# Altium schematic sheet Control Loops.SchDoc
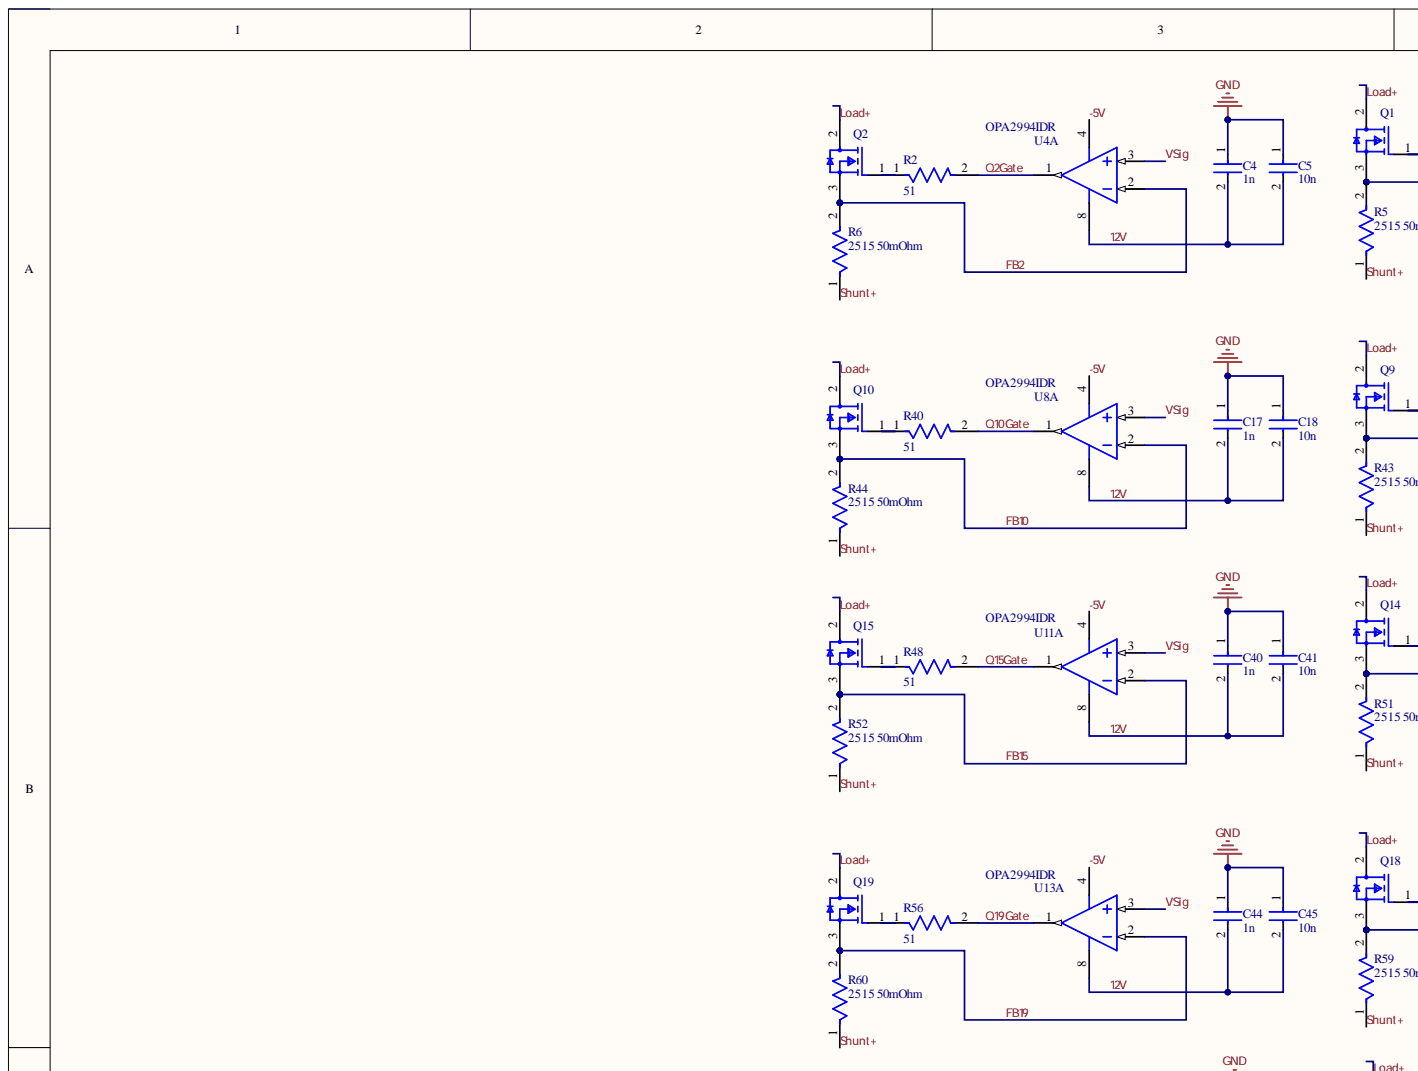
[diagram p0: Control Loops.SchDoc, top left]
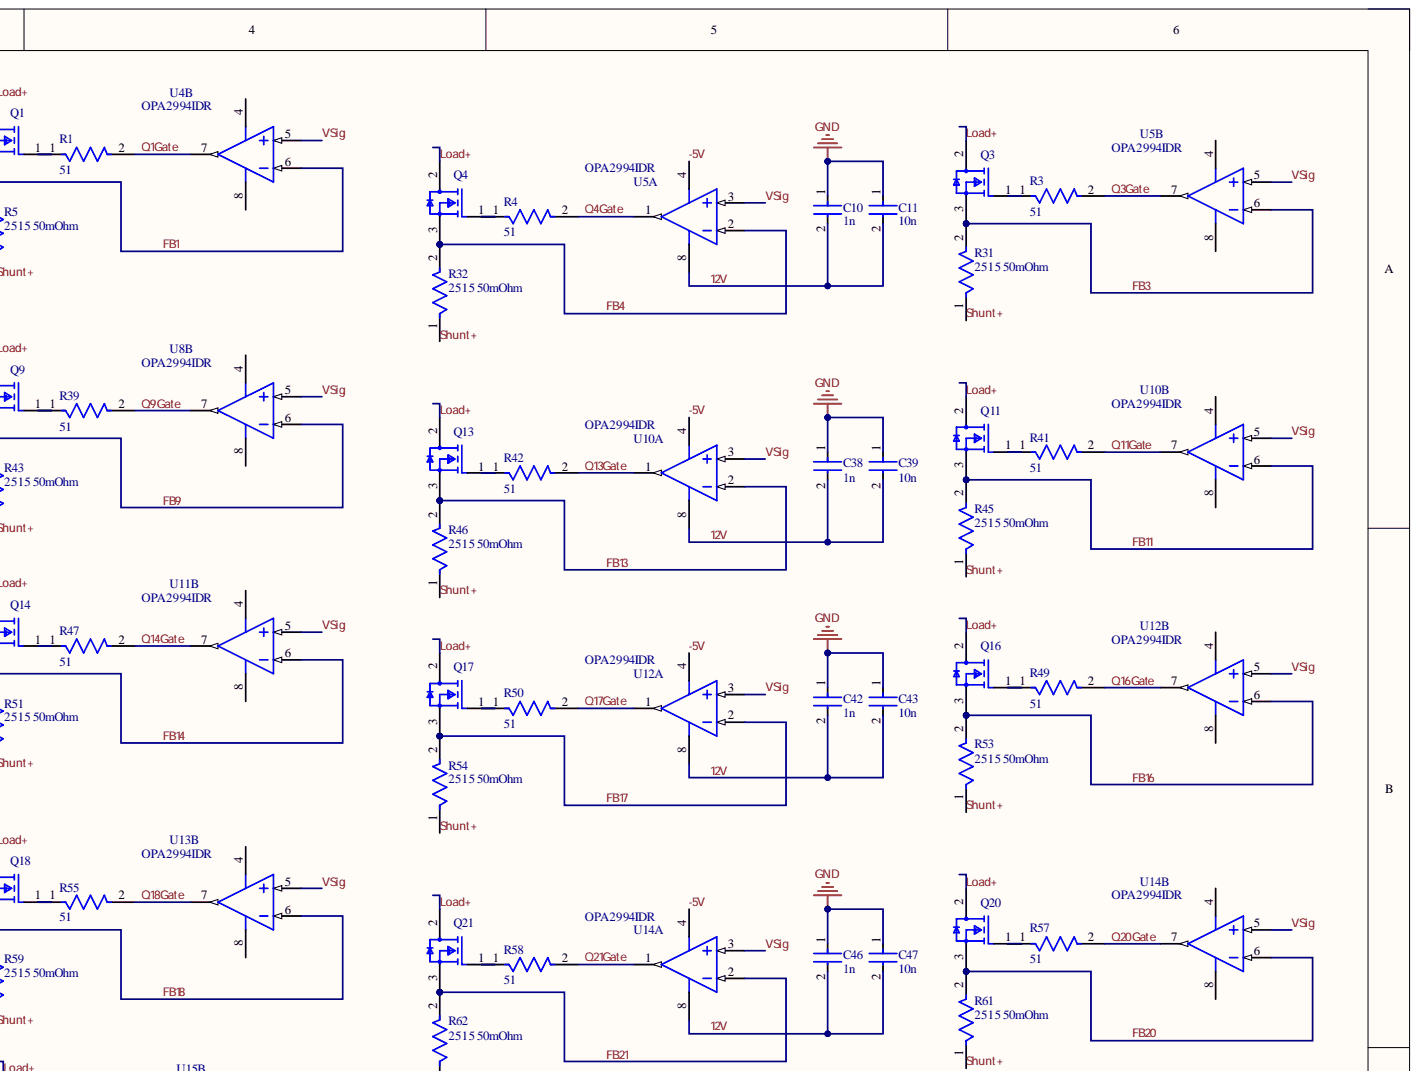
[diagram p1: Control Loops.SchDoc, top right]
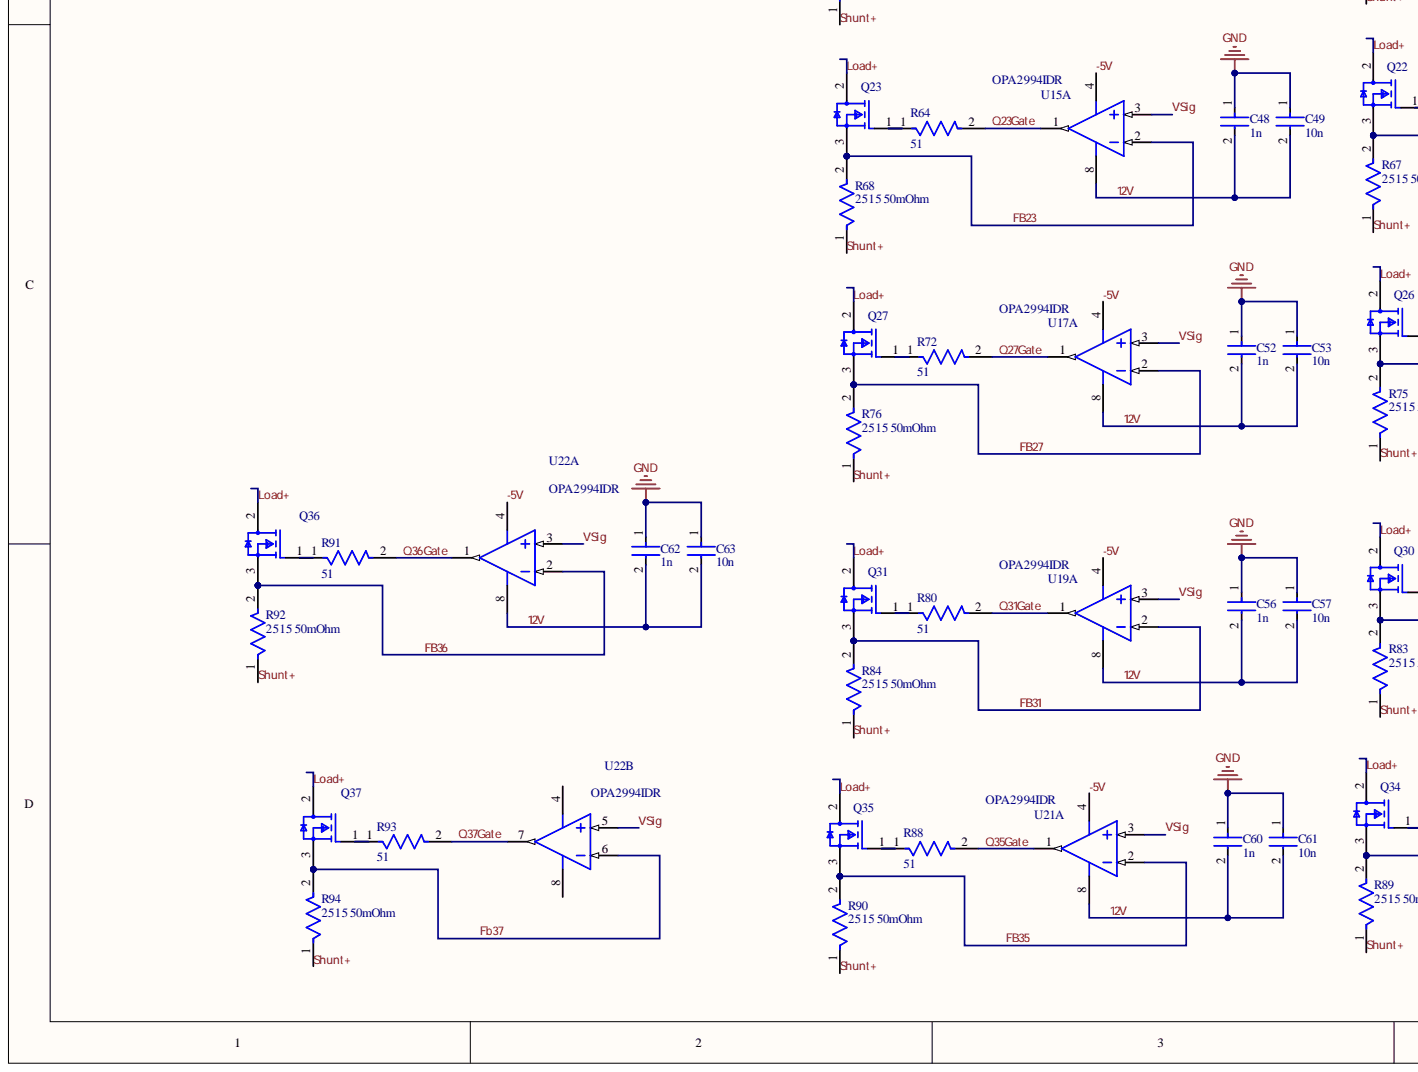
[diagram p2: Control Loops.SchDoc, bottom left]
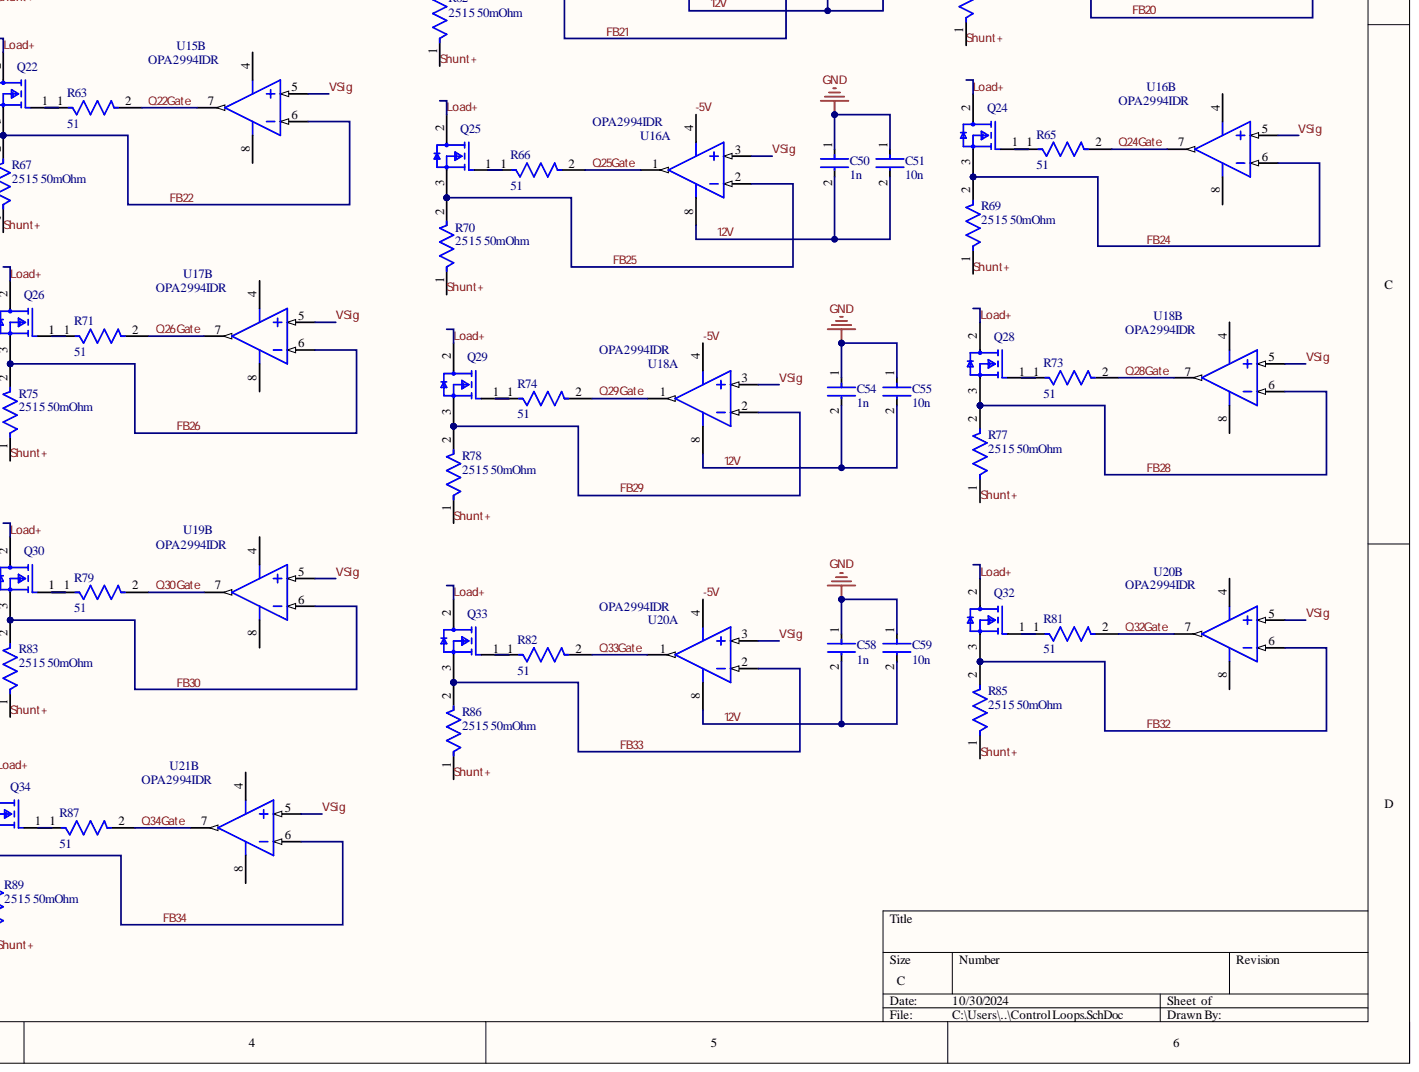
[diagram p3: Control Loops.SchDoc, bottom right]

## Parts
C4  1n  [FP-C1206C-EB-MFG]
C5  10n  [FP-C1206C-EB-MFG]
C10  1n  [FP-C1206C-EB-MFG]
C11  10n  [FP-C1206C-EB-MFG]
C17  1n  [FP-C1206C-EB-MFG]
C18  10n  [FP-C1206C-EB-MFG]
C38  1n  [FP-C1206C-EB-MFG]
C39  10n  [FP-C1206C-EB-MFG]
C40  1n  [FP-C1206C-EB-MFG]
C41  10n  [FP-C1206C-EB-MFG]
C42  1n  [FP-C1206C-EB-MFG]
C43  10n  [FP-C1206C-EB-MFG]
C44  1n  [FP-C1206C-EB-MFG]
C45  10n  [FP-C1206C-EB-MFG]
C46  1n  [FP-C1206C-EB-MFG]
C47  10n  [FP-C1206C-EB-MFG]
C48  1n  [FP-C1206C-EB-MFG]
C49  10n  [FP-C1206C-EB-MFG]
C50  1n  [FP-C1206C-EB-MFG]
C51  10n  [FP-C1206C-EB-MFG]
C52  1n  [FP-C1206C-EB-MFG]
C53  10n  [FP-C1206C-EB-MFG]
C54  1n  [FP-C1206C-EB-MFG]
C55  10n  [FP-C1206C-EB-MFG]
C56  1n  [FP-C1206C-EB-MFG]
C57  10n  [FP-C1206C-EB-MFG]
C58  1n  [FP-C1206C-EB-MFG]
C59  10n  [FP-C1206C-EB-MFG]
C60  1n  [FP-C1206C-EB-MFG]
C61  10n  [FP-C1206C-EB-MFG]
C62  1n  [FP-C1206C-EB-MFG]
C63  10n  [FP-C1206C-EB-MFG]
Q1  NVHL027N65S3F  [FP-340CX-MFG]  (value not drawn)
Q2  NVHL027N65S3F  [FP-340CX-MFG]  (value not drawn)
Q3  NVHL027N65S3F  [FP-340CX-MFG]  (value not drawn)
Q4  NVHL027N65S3F  [FP-340CX-MFG]  (value not drawn)
Q9  NVHL027N65S3F  [FP-340CX-MFG]  (value not drawn)
Q10  NVHL027N65S3F  [FP-340CX-MFG]  (value not drawn)
Q11  NVHL027N65S3F  [FP-340CX-MFG]  (value not drawn)
Q13  NVHL027N65S3F  [FP-340CX-MFG]  (value not drawn)
Q14  NVHL027N65S3F  [FP-340CX-MFG]  (value not drawn)
Q15  NVHL027N65S3F  [FP-340CX-MFG]  (value not drawn)
Q16  NVHL027N65S3F  [FP-340CX-MFG]  (value not drawn)
Q17  NVHL027N65S3F  [FP-340CX-MFG]  (value not drawn)
Q18  NVHL027N65S3F  [FP-340CX-MFG]  (value not drawn)
Q19  NVHL027N65S3F  [FP-340CX-MFG]  (value not drawn)
Q20  NVHL027N65S3F  [FP-340CX-MFG]  (value not drawn)
Q21  NVHL027N65S3F  [FP-340CX-MFG]  (value not drawn)
Q22  NVHL027N65S3F  [FP-340CX-MFG]  (value not drawn)
Q23  NVHL027N65S3F  [FP-340CX-MFG]  (value not drawn)
Q24  NVHL027N65S3F  [FP-340CX-MFG]  (value not drawn)
Q25  NVHL027N65S3F  [FP-340CX-MFG]  (value not drawn)
Q26  NVHL027N65S3F  [FP-340CX-MFG]  (value not drawn)
Q27  NVHL027N65S3F  [FP-340CX-MFG]  (value not drawn)
Q28  NVHL027N65S3F  [FP-340CX-MFG]  (value not drawn)
Q29  NVHL027N65S3F  [FP-340CX-MFG]  (value not drawn)
Q30  NVHL027N65S3F  [FP-340CX-MFG]  (value not drawn)
Q31  NVHL027N65S3F  [FP-340CX-MFG]  (value not drawn)
Q32  NVHL027N65S3F  [FP-340CX-MFG]  (value not drawn)
Q33  NVHL027N65S3F  [FP-340CX-MFG]  (value not drawn)
Q34  NVHL027N65S3F  [FP-340CX-MFG]  (value not drawn)
Q35  NVHL027N65S3F  [FP-340CX-MFG]  (value not drawn)
Q36  NVHL027N65S3F  [FP-340CX-MFG]  (value not drawn)
Q37  NVHL027N65S3F  [FP-340CX-MFG]  (value not drawn)
R1  51  [FP-RC1206-MFG]
R2  51  [FP-RC1206-MFG]
R3  51  [FP-RC1206-MFG]
R4  51  [FP-RC1206-MFG]
R5  2515 50mOhm  [FP-CSRN2512-IPC_A]
R6  2515 50mOhm  [FP-CSRN2512-IPC_A]
R31  2515 50mOhm  [FP-CSRN2512-IPC_A]
R32  2515 50mOhm  [FP-CSRN2512-IPC_A]
R39  51  [FP-RC1206-MFG]
R40  51  [FP-RC1206-MFG]
R41  51  [FP-RC1206-MFG]
R42  51  [FP-RC1206-MFG]
R43  2515 50mOhm  [FP-CSRN2512-IPC_A]
R44  2515 50mOhm  [FP-CSRN2512-IPC_A]
R45  2515 50mOhm  [FP-CSRN2512-IPC_A]
R46  2515 50mOhm  [FP-CSRN2512-IPC_A]
R47  51  [FP-RC1206-MFG]
R48  51  [FP-RC1206-MFG]
R49  51  [FP-RC1206-MFG]
R50  51  [FP-RC1206-MFG]
R51  2515 50mOhm  [FP-CSRN2512-IPC_A]
R52  2515 50mOhm  [FP-CSRN2512-IPC_A]
R53  2515 50mOhm  [FP-CSRN2512-IPC_A]
R54  2515 50mOhm  [FP-CSRN2512-IPC_A]
R55  51  [FP-RC1206-MFG]
R56  51  [FP-RC1206-MFG]
R57  51  [FP-RC1206-MFG]
R58  51  [FP-RC1206-MFG]
R59  2515 50mOhm  [FP-CSRN2512-IPC_A]
R60  2515 50mOhm  [FP-CSRN2512-IPC_A]
R61  2515 50mOhm  [FP-CSRN2512-IPC_A]
R62  2515 50mOhm  [FP-CSRN2512-IPC_A]
R63  51  [FP-RC1206-MFG]
R64  51  [FP-RC1206-MFG]
R65  51  [FP-RC1206-MFG]
R66  51  [FP-RC1206-MFG]
R67  2515 50mOhm  [FP-CSRN2512-IPC_A]
R68  2515 50mOhm  [FP-CSRN2512-IPC_A]
R69  2515 50mOhm  [FP-CSRN2512-IPC_A]
R70  2515 50mOhm  [FP-CSRN2512-IPC_A]
R71  51  [FP-RC1206-MFG]
R72  51  [FP-RC1206-MFG]
R73  51  [FP-RC1206-MFG]
R74  51  [FP-RC1206-MFG]
R75  2515 50mOhm  [FP-CSRN2512-IPC_A]
R76  2515 50mOhm  [FP-CSRN2512-IPC_A]
R77  2515 50mOhm  [FP-CSRN2512-IPC_A]
R78  2515 50mOhm  [FP-CSRN2512-IPC_A]
R79  51  [FP-RC1206-MFG]
R80  51  [FP-RC1206-MFG]
R81  51  [FP-RC1206-MFG]
R82  51  [FP-RC1206-MFG]
R83  2515 50mOhm  [FP-CSRN2512-IPC_A]
R84  2515 50mOhm  [FP-CSRN2512-IPC_A]
R85  2515 50mOhm  [FP-CSRN2512-IPC_A]
R86  2515 50mOhm  [FP-CSRN2512-IPC_A]
R87  51  [FP-RC1206-MFG]
R88  51  [FP-RC1206-MFG]
R89  2515 50mOhm  [FP-CSRN2512-IPC_A]
R90  2515 50mOhm  [FP-CSRN2512-IPC_A]
R91  51  [FP-RC1206-MFG]
R92  2515 50mOhm  [FP-CSRN2512-IPC_A]
R93  51  [FP-RC1206-MFG]
R94  2515 50mOhm  [FP-CSRN2512-IPC_A]
U4  OPA2994IDR  [D0008A_N]  (parts A,B)
U5  OPA2994IDR  [D0008A_N]  (parts A,B)
U8  OPA2994IDR  [D0008A_N]  (parts A,B)
U10  OPA2994IDR  [D0008A_N]  (parts A,B)
U11  OPA2994IDR  [D0008A_N]  (parts A,B)
U12  OPA2994IDR  [D0008A_N]  (parts A,B)
U13  OPA2994IDR  [D0008A_N]  (parts A,B)
U14  OPA2994IDR  [D0008A_N]  (parts A,B)
U15  OPA2994IDR  [D0008A_N]  (parts A,B)
U16  OPA2994IDR  [D0008A_N]  (parts A,B)
U17  OPA2994IDR  [D0008A_N]  (parts A,B)
U18  OPA2994IDR  [D0008A_N]  (parts A,B)
U19  OPA2994IDR  [D0008A_N]  (parts A,B)
U20  OPA2994IDR  [D0008A_N]  (parts A,B)
U21  OPA2994IDR  [D0008A_N]  (parts A,B)
U22  OPA2994IDR  [D0008A_N]  (parts A,B)

## Nets
GND: C4.1 C5.1 C10.1 C11.1 C17.1 C18.1 C38.1 C39.1 C40.1 C41.1 C42.1 C43.1 C44.1 C45.1 C46.1 C47.1 C48.1 C49.1 C50.1 C51.1 C52.1 C53.1 C54.1 C55.1 C56.1 C57.1 C58.1 C59.1 C60.1 C61.1 C62.1 C63.1
12V: C4.2 C5.2 C10.2 C11.2 C17.2 C18.2 C38.2 C39.2 C40.2 C41.2 C42.2 C43.2 C44.2 C45.2 C46.2 C47.2 C48.2 C49.2 C50.2 C51.2 C52.2 C53.2 C54.2 C55.2 C56.2 C57.2 C58.2 C59.2 C60.2 C61.2 C62.2 C63.2 U4.8 U5.8 U8.8 U10.8 U11.8 U12.8 U13.8 U14.8 U15.8 U16.8 U17.8 U18.8 U19.8 U20.8 U21.8 U22.8
-5V: U4.4 U5.4 U8.4 U10.4 U11.4 U12.4 U13.4 U14.4 U15.4 U16.4 U17.4 U18.4 U19.4 U20.4 U21.4 U22.4
FB1: Q1.3 Q37.3 R5.2 R94.2 U4.6 U22.6  [also labelled Fb37]
FB2: Q2.3 R6.2 U4.2
FB3: Q3.3 R31.2 U5.6
FB4: Q4.3 R32.2 U5.2
FB9: Q9.3 R43.2 U8.6
FB10: Q10.3 R44.2 U8.2
FB11: Q11.3 R45.2 U10.6
FB13: Q13.3 R46.2 U10.2
FB14: Q14.3 R51.2 U11.6
FB15: Q15.3 R52.2 U11.2
FB16: Q16.3 R53.2 U12.6
FB17: Q17.3 R54.2 U12.2
FB18: Q18.3 R59.2 U13.6
FB19: Q19.3 R60.2 U13.2
FB20: Q20.3 R61.2 U14.6
FB21: Q21.3 R62.2 U14.2
FB22: Q22.3 R67.2 U15.6
FB23: Q23.3 R68.2 U15.2
FB24: Q24.3 R69.2 U16.6
FB25: Q25.3 R70.2 U16.2
FB26: Q26.3 R75.2 U17.6
FB27: Q27.3 R76.2 U17.2
FB28: Q28.3 R77.2 U18.6
FB29: Q29.3 R78.2 U18.2
FB30: Q30.3 R83.2 U19.6
FB31: Q31.3 R84.2 U19.2
FB32: Q32.3 R85.2 U20.6
FB33: Q33.3 R86.2 U20.2
FB34: Q34.3 R89.2 U21.6
FB35: Q35.3 R90.2 U21.2
FB36: Q36.3 R92.2 U22.2
Load+: Q1.2 Q2.2 Q3.2 Q4.2 Q9.2 Q10.2 Q11.2 Q13.2 Q14.2 Q15.2 Q16.2 Q17.2 Q18.2 Q19.2 Q20.2 Q21.2 Q22.2 Q23.2 Q24.2 Q25.2 Q26.2 Q27.2 Q28.2 Q29.2 Q30.2 Q31.2 Q32.2 Q33.2 Q34.2 Q35.2 Q36.2 Q37.2
Q1Gate: R1.2 U4.7
Q2Gate: R2.2 U4.1
Q3Gate: R3.2 U5.7(Q3Gate)
Q4Gate: R4.2 U5.1
Q9Gate: R39.2 U8.7
Q10Gate: R40.2 U8.1
Q11Gate: R41.2 U10.7
Q13Gate: R42.2 U10.1
Q14Gate: R47.2 U11.7
Q15Gate: R48.2 U11.1
Q16Gate: R49.2 U12.7
Q17Gate: R50.2 U12.1
Q18Gate: R55.2 U13.7
Q19Gate: R56.2 U13.1
Q20Gate: R57.2 U14.7
Q21Gate: R58.2 U14.1
Q22Gate: R63.2 U15.7
Q23Gate: R64.2 U15.1
Q24Gate: R65.2 U16.7
Q25Gate: R66.2 U16.1
Q26Gate: R71.2 U17.7
Q27Gate: R72.2 U17.1
Q28Gate: R73.2 U18.7
Q29Gate: R74.2 U18.1
Q30Gate: R79.2 U19.7
Q31Gate: R80.2 U19.1
Q32Gate: R81.2 U20.7
Q33Gate: R82.2 U20.1
Q34Gate: R87.2 U21.7
Q35Gate: R88.2 U21.1
Q36Gate: R91.2 U22.1
Q37Gate: R93.2 U22.7
Shunt+: R5.1 R6.1 R31.1 R32.1 R43.1 R44.1 R45.1 R46.1 R51.1 R52.1 R53.1 R54.1 R59.1 R60.1 R61.1 R62.1 R67.1 R68.1 R69.1 R70.1 R75.1 R76.1 R77.1 R78.1 R83.1 R84.1 R85.1 R86.1 R89.1 R90.1 R92.1 R94.1
VSig: U4.3 U4.5 U5.3 U5.5 U8.3 U8.5 U10.3 U10.5 U11.3 U11.5 U12.3 U12.5 U13.3 U13.5 U14.3 U14.5 U15.3 U15.5 U16.3 U16.5 U17.3 U17.5 U18.3 U18.5 U19.3 U19.5 U20.3 U20.5 U21.3 U21.5 U22.3 U22.5
NetQ1_1: Q1.1 R1.1
NetQ2_1: Q2.1 R2.1
NetQ3_1: Q3.1 R3.1
NetQ4_1: Q4.1 R4.1
NetQ9_1: Q9.1 R39.1
NetQ10_1: Q10.1 R40.1
NetQ11_1: Q11.1 R41.1
NetQ13_1: Q13.1 R42.1
NetQ14_1: Q14.1 R47.1
NetQ15_1: Q15.1 R48.1
NetQ16_1: Q16.1 R49.1
NetQ17_1: Q17.1 R50.1
NetQ18_1: Q18.1 R55.1
NetQ19_1: Q19.1 R56.1
NetQ20_1: Q20.1 R57.1
NetQ21_1: Q21.1 R58.1
NetQ22_1: Q22.1 R63.1
NetQ23_1: Q23.1 R64.1
NetQ24_1: Q24.1 R65.1
NetQ25_1: Q25.1 R66.1
NetQ26_1: Q26.1 R71.1
NetQ27_1: Q27.1 R72.1
NetQ28_1: Q28.1 R73.1
NetQ29_1: Q29.1 R74.1
NetQ30_1: Q30.1 R79.1
NetQ31_1: Q31.1 R80.1
NetQ32_1: Q32.1 R81.1
NetQ33_1: Q33.1 R82.1
NetQ34_1: Q34.1 R87.1
NetQ35_1: Q35.1 R88.1
NetQ36_1: Q36.1 R91.1
NetQ37_1: Q37.1 R93.1

## Library data of the parts
C4 C5 C10 C11 C17 C18 C38-C63 = CMP-03020-000153-1: CAP CER 0.1UF 100V X7R 1206; Manufacturer: KEMET; Manufacturer Part Number: C1206C104K1RACTU; Automotive Grade: Grade 0; Capacitance: 0.10 μF; Material: Ceramic; Temperature Coefficient: 15%; Tolerance: 0.1; Value: 0.10 μF; Automotive: Yes; Category: Cap; Component Kind: Standard; ComponentLink2Description: Datasheet; ComponentLink2URL: https://content.kemet.com/datasheets/KEM_C1007_X8R_ULTRA_150C_SMD.pdf; Device Class L1: Passive Components; Device Class L2: Capacitors; Device Class L3: Ceramic Capacitors; Digikey Description: CAP CER 0.1UF 100V X7R 1206; Height: 0.88mm; Ipc Land Pattern Name: CAPC320160X78; Lead Free: Yes; Library Name: Altium Content Vault; Mouser Description: CAP CER 0.1UF 100V X7R 1206; Octopart: https://octopart.com/c1206c104k1ractu-kemet-89521; Package: C1206C-EB; RoHS: TRUE; Temperature: -55°C to +150°C; Temperature Characteristic: X7R; Temperature Range High: +150°C; Temperature Range Low: -55°C; Voltage Rating: 100 V
Q1-Q4 Q9-Q11 Q13-Q37 = CMP-00278-97862318-1: NVHL027N65S3F Single N-Channel Power MOSFET SUPERFET® III, FRFET®, 650 V , 75 A, 27.4 mΩ, TO-247; Manufacturer Lifecycle Status: ACTIVE; Continuous Drain Current (ID): 75A; Drain to Source Breakdown Voltage: 650V; Drain to Source Resistance: 21.5mΩ; Gate to Source Voltage (Vgs): 30V; Max Junction Temperature (Tj): 150°C; Number of Channels: 1; Power Dissipation: 595W; Turn-Off Delay Time: 147ns; Turn-On Delay Time: 46ns; Component Kind: Standard; Drain to Source Voltage (Vdss): 650V; Height: 24.75mm; Library Name: Altium Content Vault; Lifecycle Status: Production; Max Operating Temperature: 150°C; Min Operating Temperature: -55°C; NexarPartId: 97862318; RoHS: Compliant; Schedule B: 8541290080, 8541290080
R1-R4 R39-R42 R47-R50 R55-R58 R63-R66 R71-R74 R79-R82 R87 R88 R91 R93 = CMP-2100-03681-2: RES SMD 0 OHM JUMPER 1/4W 1206; Manufacturer: YAGEO; Manufacturer Part Number: RC1206JR-070RL; Power Rating: 0.25W; Resistance: 0Ω; Temperature Coefficient: 300ppm/°C; Tolerance: 5%; Value: 0Ω; Voltage Rating: 200V; Automotive: No; Category: Res; Component Kind: Standard; ComponentLink2Description: Datasheet; ComponentLink2URL: https://www.yageo.com/upload/media/product/productsearch/datasheet/rchip/PYu-RC_Group_51_RoHS_L_10.pdf; Composition: Thick Film; Device Class L1: Passive Components; Device Class L2: Resistors; Device Class L3: Chip SMD Resistors; Digikey Description: RES SMD 0 OHM JUMPER 1/4W 1206; Footprint Url: https://www.yageo.com/upload/website/yageo_PYu-R_Mount_10_19050818_343.pdf; Height: 0.65mm; Ipc Land Pattern Name: RESC310160X55; Lead Free: Yes; Library Name: Altium Content Vault; Mouser Description: Resistor: thick film; SMD; 1206; 0O; 0.25W; ±5%; -55÷155°C; Octopart: https://octopart.com/rc1206jr-070rl-yageo-40025601; Package: RC1206; RoHS: TRUE; Series: RC; Temperature: -55°C to +155°C; Temperature Range High: +155°C; Temperature Range Low: -55°C
R5 R6 R31 R32 R43-R46 R51-R54 R59-R62 R67-R70 R75-R78 R83-R86 R89 R90 R92 R94 = CMP-26527-006831-1: RCWE251250L0FKEA; Max Power Dissipation: 2W; Resistance: 500mR; Temperature Coefficient: 200ppm/°C; Tolerance: 1%; Case Code (Imperial): 2512; Case Code (Metric): 6432; Case/Package: 2512; Component Kind: Standard; Construction: Rectangular; Contact Plating: Tin; Depth: 3.15mm; Height: 0.028inch; Lead Free: Lead Free; Length: 6.35mm; Library Name: Altium Content Vault; Max Operating Temperature: 155°C; Military Standard: Not; Min Operating Temperature: -55°C; Mount: Surface Mount; Number of Terminations: 2; Packaging: Tape and Reel; Power Rating: 2W; Radiation Hardening: No; Resistor Type: Thick Film; RoHS Compliant: Yes; Series: CSRN; Size Code: 2512; Width: 0.124inch
U4 U5 U8 U10-U22 = CMP-0272-00265-3: High Precision, Low Noise Operational Amplifier, 5 to 36 V, -40 to 85 degC, 8-pin SOIC (D8), Green (RoHS & no Sb/Br); Manufacturer: Texas Instruments; PartNumber: OPA2227UA; CMRR(Min)(dB): 120; GBW(Typ)(MHz): 8; IIB(Max)(pA): 10000; Iq per channel(Max)(mA): 3.8; Number of Channels: 2; Offset Drift(Typ)(uV/C): 0.3; Packing: TUBE; Rating: Catalog; Slew Rate(Typ)(V/us): 2.3; Sub Family: High Supply Voltage Amplifier (>=30V), Low Noise Amp (<=10nV/rtHz), Low Offset Voltage Amplifier (<=500uV), Precision Amplifier; Total Supply Voltage(Max)(+5V is 5, +/-5V is 10): 36; Total Supply Voltage(Min)(+5V is 5, +/-5V is 10): 5; Vio (25C)(Max)(mV): 0.2; Code_JEDEC: MS-012; Component Kind: Standard; ComponentLink1Description: Manufacturer URL; ComponentLink1URL: http://www.ti.com/; ComponentLink2Description: Datasheet; ComponentLink2URL: http://www.ti.com/lit/ds/symlink/opa2227.pdf; ComponentLink3Description: Package Specification; ComponentLink3URL: http://www.ti.com/litv/pdf/msoi002j; DatasheetVersion: SBOS110A; Eco-Plan: Green (RoHS & no Sb/Br); Library Name: Altium Content Vault; Mounting Technology: Surface Mount; PackageDescription: 8-Pin Plastic Small Outline, Body 4.9 x 3.9 mm, Pitch 1.27 mm; PackageReference: D0008A; PackageVersion: 4040047-3/M, 06/2011
